# Altium schematic sheet Sheet2.SchDoc(Sheet2)
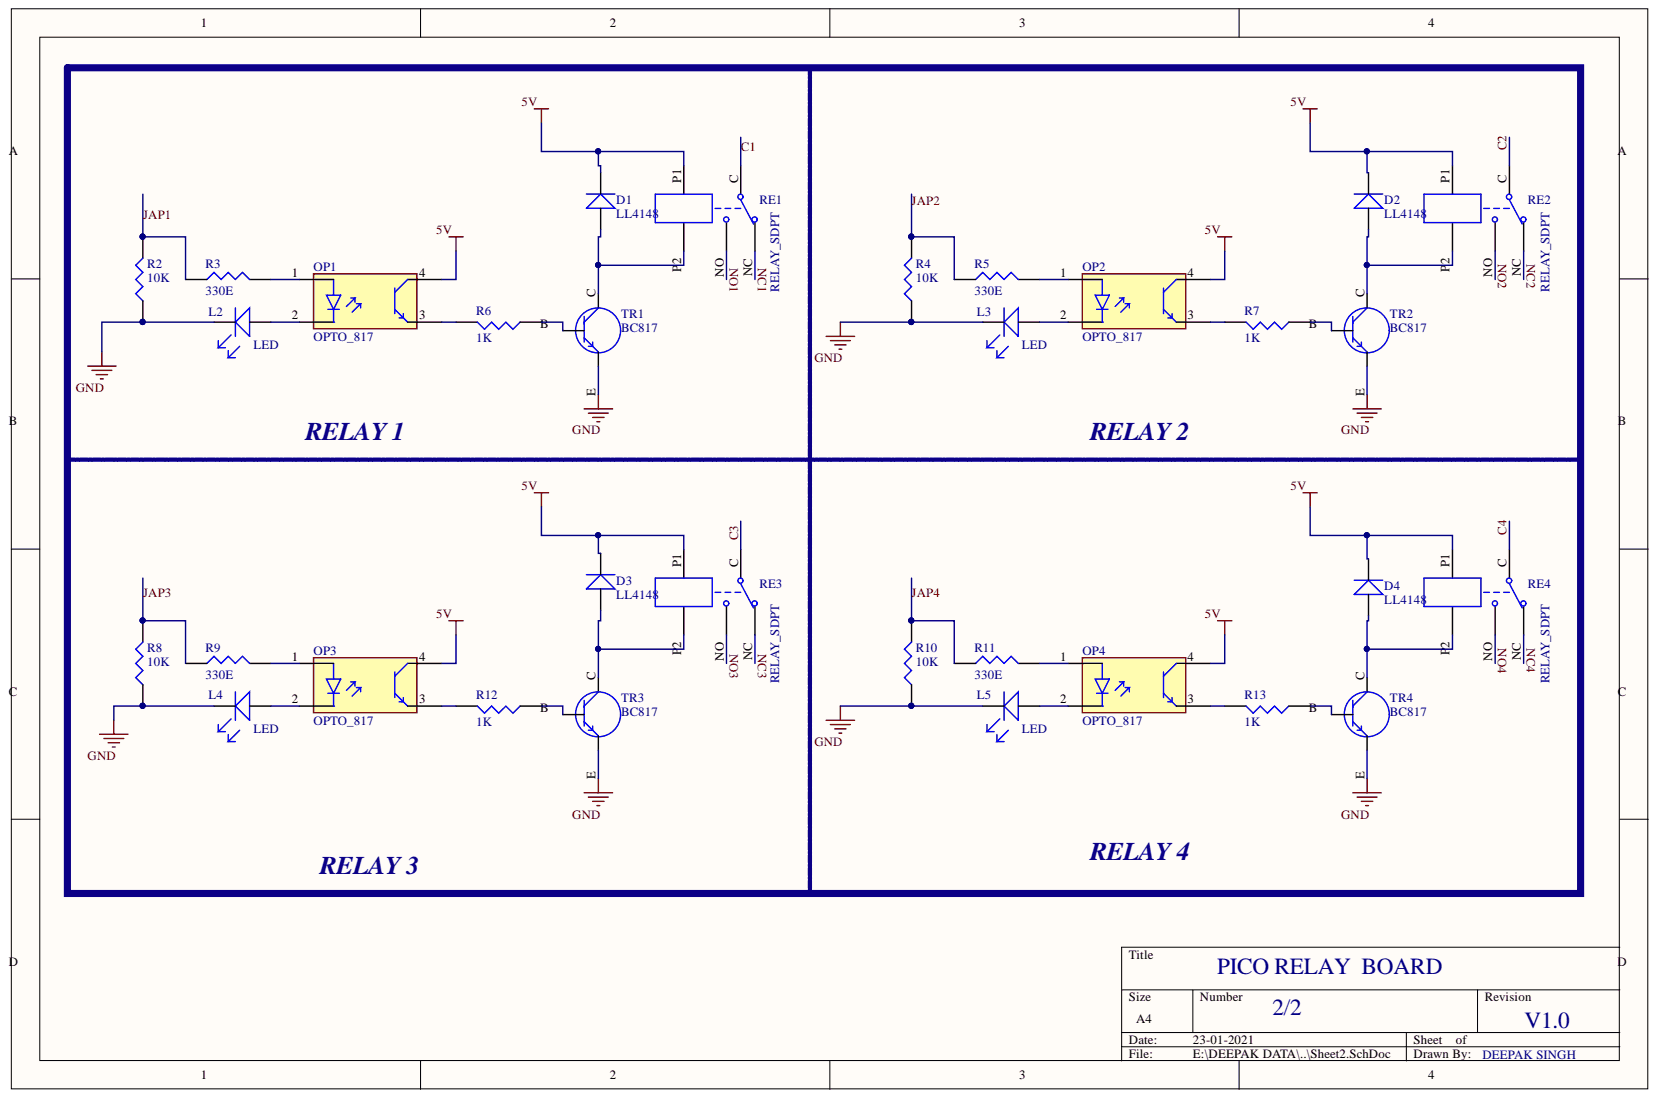
[diagram p0: Sheet2.SchDoc]

## Parts
D1  LL4148  [MINI_MELF_SOD80]
D2  LL4148  [MINI_MELF_SOD80]
D3  LL4148  [MINI_MELF_SOD80]
D4  LL4148  [MINI_MELF_SOD80]
L2  LED  [LED_0603_neg]
L3  LED  [LED_0603_neg]
L4  LED  [LED_0603_neg]
L5  LED  [LED_0603_neg]
OP1  OPTO_817  [OPTO_817_SMD]
OP2  OPTO_817  [OPTO_817_SMD]
OP3  OPTO_817  [OPTO_817_SMD]
OP4  OPTO_817  [OPTO_817_SMD]
R2  10K  [0603]
R3  330E  [0603]
R4  10K  [0603]
R5  330E  [0603]
R6  1K  [0603]
R7  1K  [0603]
R8  10K  [0603]
R9  330E  [0603]
R10  10K  [0603]
R11  330E  [0603]
R12  1K  [0603]
R13  1K  [0603]
RE1  RELAY_SDPT  [RELAY_SDPT]
RE2  RELAY_SDPT  [RELAY_SDPT]
RE3  RELAY_SDPT  [RELAY_SDPT]
RE4  RELAY_SDPT  [RELAY_SDPT]
TR1  BC817  [547_SOT23]
TR2  BC817  [547_SOT23]
TR3  BC817  [547_SOT23]
TR4  BC817  [547_SOT23]

## Nets
5V: D1.K D2.K D3.K D4.K OP1.4 OP2.4 OP3.4 OP4.4 RE1.P1 RE2.P1 RE3.P1 RE4.P1
GND: L2.K L3.K L4.K L5.K R2.1 R4.1 R8.1 R10.1 TR1.E TR2.E TR3.E TR4.E
C1: RE1.C
C2: RE2.C
C3: RE3.C
C4: RE4.C
JAP1: R2.2 R3.1
JAP2: R4.2 R5.1
JAP3: R8.2 R9.1
JAP4: R10.2 R11.1
NC1: RE1.NC
NC2: RE2.NC
NC3: RE3.NC
NC4: RE4.NC
NO1: RE1.NO
NO2: RE2.NO
NO3: RE3.NO
NO4: RE4.NO
NetD1_A: D1.A RE1.P2 TR1.C
NetD2_A: D2.A RE2.P2 TR2.C
NetD3_A: D3.A RE3.P2 TR3.C
NetD4_A: D4.A RE4.P2 TR4.C
NetL2_A: L2.A OP1.2
NetL3_A: L3.A OP2.2
NetL4_A: L4.A OP3.2
NetL5_A: L5.A OP4.2
NetOP1_1: OP1.1 R3.2
NetOP1_3: OP1.3 R6.2
NetOP2_1: OP2.1 R5.2
NetOP2_3: OP2.3 R7.2
NetOP3_1: OP3.1 R9.2
NetOP3_3: OP3.3 R12.2
NetOP4_1: OP4.1 R11.2
NetOP4_3: OP4.3 R13.2
NetR6_1: R6.1 TR1.B
NetR7_1: R7.1 TR2.B
NetR12_1: R12.1 TR3.B
NetR13_1: R13.1 TR4.B

## Library data of the parts
D1-D4 = DIODE: Component Kind: Standard; Library Name: dxp_pasl_lib.IntLib
R2-R13 = RES: Component Kind: Standard; Library Name: dxp_pasl_lib.IntLib
TR1-TR4 = TRANSISTER_NPN: Component Kind: Standard; Library Name: dxp_pasl_lib.IntLib
L2-L5 = LED: Component Kind: Standard; Library Name: dxp_pasl_lib.IntLib
OP1-OP4 = OPTO_817: Component Kind: Standard; Library Name: dxp_pasl_lib.IntLib
RE1-RE4 = RELAY_SDPT: Component Kind: Standard; Library Name: dxp_pasl_lib.IntLib
